# Altium schematic sheet SCH001 - 14-port switch Power & Voltage converter.SchDoc
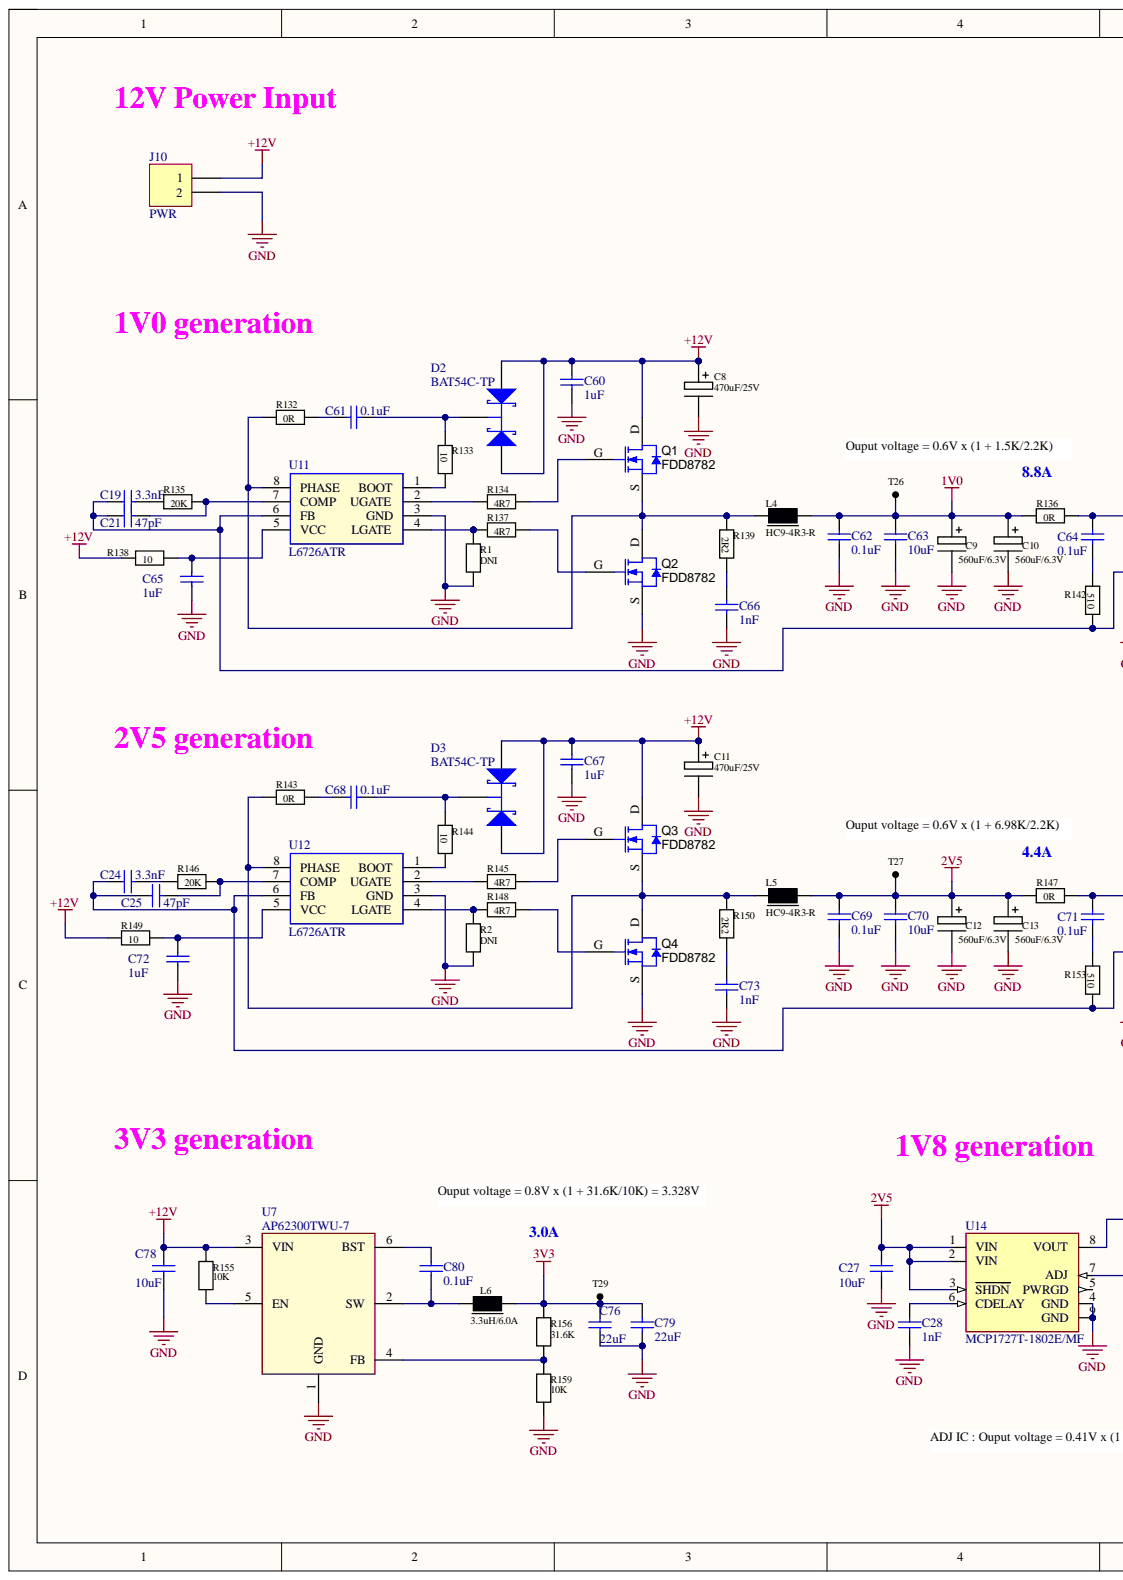
[diagram p0: SCH001 - 14-port switch Power & Voltage converter.SchDoc, left]
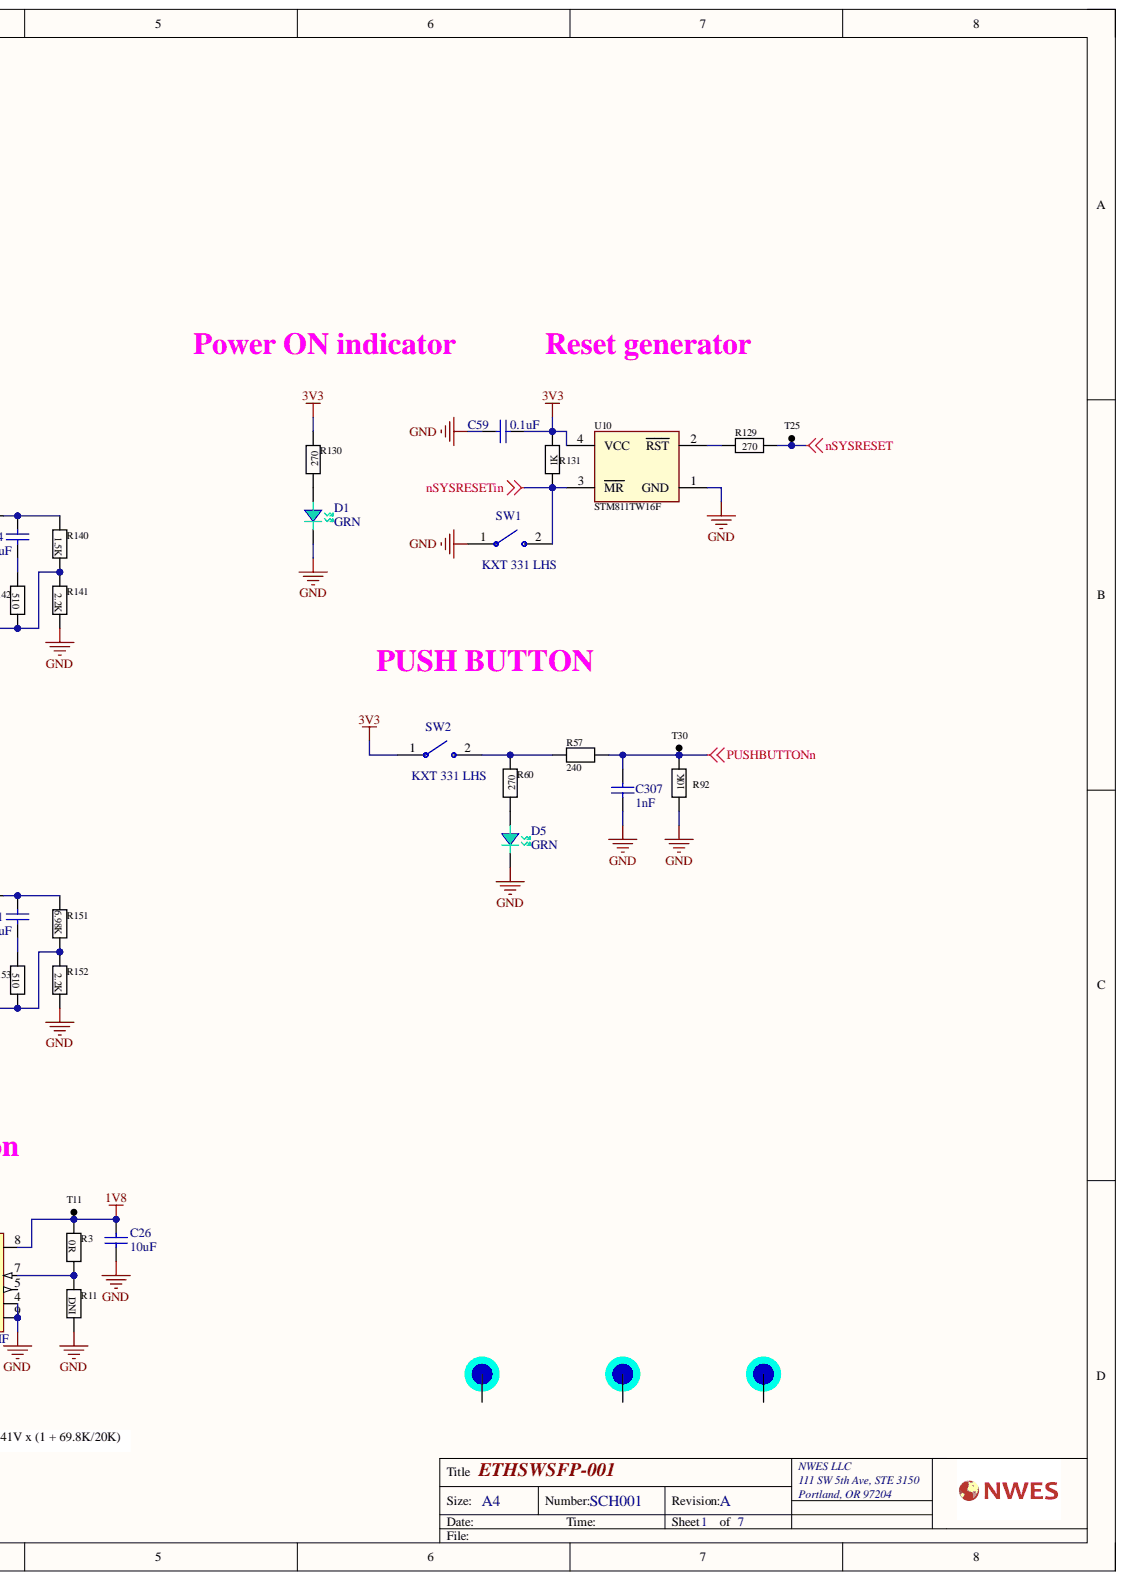
[diagram p1: SCH001 - 14-port switch Power & Voltage converter.SchDoc, right]

## Parts
C8  470uF/25V  [CAPAE1030X1680N]
C9  560uF/6.3V  [ELEC_8.3_8.3_7]
C10  560uF/6.3V  [ELEC_8.3_8.3_7]
C11  470uF/25V  [CAPAE1030X1680N]
C12  560uF/6.3V  [ELEC_8.3_8.3_7]
C13  560uF/6.3V  [ELEC_8.3_8.3_7]
C19  3.3nF  [CAPC1005X55USR]
C21  47pF  [CAPC1005X55USR]
C24  3.3nF  [CAPC1005X55USR]
C25  47pF  [CAPC1005X55USR]
C26  10uF  [CAPC1005X61USR]
C27  10uF  [CAPC1005X61USR]
C28  1nF  [CAPC1005X61USR]
C59  0.1uF  [CAPC1005X61USR]
C60  1uF  [CAPC1005X61USR]
C61  0.1uF  [CAPC1005X61USR]
C62  0.1uF  [CAPC1005X61USR]
C63  10uF  [CAPC1005X61USR]
C64  0.1uF  [CAPC1005X61USR]
C65  1uF  [CAPC1005X61USR]
C66  1nF  [CAPC1005X61USR]
C67  1uF  [CAPC1005X61USR]
C68  0.1uF  [CAPC1005X61USR]
C69  0.1uF  [CAPC1005X61USR]
C70  10uF  [CAPC1005X61USR]
C71  0.1uF  [CAPC1005X61USR]
C72  1uF  [CAPC1005X61USR]
C73  1nF  [CAPC1005X61USR]
C76  22uF  [CAP_0603]
C78  10uF  [CAPC1005X61USR]
C79  22uF  [CAP_0603]
C80  0.1uF  [CAPC1005X61USR]
C307  1nF  [CAPC1005X61USR]
D1  GRN  [LED_0603]
D2  BAT54C-TP  [SOT-23]
D3  BAT54C-TP  [SOT-23]
D5  GRN  [LED_0603]
J10  PWR  [MOLEX_282834-2]
L4  HC9-4R3-R  [HC9-4R3-R]
L5  HC9-4R3-R  [HC9-4R3-R]
L6  3.3uH/6.0A  [INDPM7366X300N]
MH1  MH_1.5mm  [MH_3mm]  (value not drawn)  (no BOM)
MH2  MH_1.5mm  [MH_3mm]  (value not drawn)  (no BOM)
MH3  MH_1.5mm  [MH_3mm]  (value not drawn)  (no BOM)
Q1  FDD8782  [TO-252]
Q2  FDD8782  [TO-252]
Q3  FDD8782  [TO-252]
Q4  FDD8782  [TO-252]
R1  DNI  [RESC1005X40USR]
R2  DNI  [RESC1005X40USR]
R3  0R  [RESC1005X40USR]
R11  DNI  [RESC1005X40USR]
R57  240  [RESC1005X40USR]
R60  270  [RESC1005X40USR]
R92  10K  [RESC1005X40USR]
R129  270  [RESC1005X40USR]
R130  270  [RESC1005X40USR]
R131  1K  [RESC1005X40USR]
R132  0R  [RESC1005X40USR]
R133  10  [RESC3116X65N]
R134  4R7  [RESC2012X65USR]
R135  20K  [RESC1005X40USR]
R136  0R  [RESC1005X40USR]
R137  4R7  [RESC2012X65USR]
R138  10  [RESC3116X65N]
R139  2R2  [RESC2012X65USR]
R140  1.5K  [RESC1005X40USR]
R141  2.2K  [RESC1005X40USR]
R142  510  [RESC1005X40USR]
R143  0R  [RESC1005X40USR]
R144  10  [RESC3116X65N]
R145  4R7  [RESC2012X65USR]
R146  20K  [RESC1005X40USR]
R147  0R  [RESC1005X40USR]
R148  4R7  [RESC2012X65USR]
R149  10  [RESC3116X65N]
R150  2R2  [RESC2012X65USR]
R151  6.98K  [RESC1005X40USR]
R152  2.2K  [RESC1005X40USR]
R153  510  [RESC1005X40USR]
R155  10K  [RESC1005X40USR]
R156  31.6K  [RESC1005X40USR]
R159  10K  [RESC1005X40USR]
SW1  KXT 331 LHS  [SW_KXT 331]
SW2  KXT 331 LHS  [SW_KXT 331]
T11  TP_S  [TP 1mm]  (value not drawn)  (no BOM)
T25  TP_S  [TP 1mm]  (value not drawn)  (no BOM)
T26  TP_S  [TP 1mm]  (value not drawn)  (no BOM)
T27  TP_S  [TP 1mm]  (value not drawn)  (no BOM)
T29  TP_S  [TP 1mm]  (value not drawn)  (no BOM)
T30  TP_S  [TP 1mm]  (value not drawn)  (no BOM)
U7  AP62300TWU-7  [TSOT23-6]
U10  STM811TW16F  [SOT23-4]
U11  L6726ATR  [SOIC127P600X175-8N]
U12  L6726ATR  [SOIC127P600X175-8N]
U14  MCP1727T-1802E/MF  [DFN300X300X100-9N]

## Nets
1V0: C9.1 C10.1 C62.1 C63.1 L4.2 R136.2 T26.1
1V8: C26.1 R3.1 T11.1 U14.8(VOUT)
2V5: C12.1 C13.1 C27.1 C69.1 C70.1 L5.2 R147.2 T27.1 U14.1(VIN) U14.2(VIN) U14.3(SHDN)
3V3: C59.1 C76.2 C79.1 L6.2 R130.1 R131.1 R156.2 SW2.1 T29.1 U10.4(VCC)
+12V: C8.1 C11.1 C60.2 C67.2 C78.1 D2.1 D2.2 D3.1 D3.2 J10.1 Q1.D Q3.D R138.2 R149.2 R155.1 U7.3(VIN)
GND: C8.2 C9.2 C10.2 C11.2 C12.2 C13.2 C26.2 C27.2 C28.1 C59.2 C60.1 C62.2 C63.2 C65.1 C66.1 C67.1 C69.2 C70.2 C72.1 C73.1 C76.1 C78.2 C79.2 C307.2 D1.2 D5.2 J10.2 Q2.S Q4.S R1.2 R2.2 R11.2 R92.2 R141.2 R152.2 R159.2 SW1.1 U7.1(GND) U10.1(GND) U11.3(GND) U12.3(GND) U14.4(GND) U14.9(GND)
nSYSRESET: R129.2 T25.1
nSYSRESETin: R131.2 SW1.2 U10.3(MR)
PUSHBUTTONn: C307.1 R57.2 R92.1 T30.1
NetC19_1: C19.1 C21.1 R140.2 R141.1 R142.2 U11.6(FB)
NetC19_2: C19.2 R135.1
NetC21_2: C21.2 R135.2 U11.7(COMP)
NetC24_1: C24.1 C25.1 R151.2 R152.1 R153.2 U12.6(FB)
NetC24_2: C24.2 R146.1
NetC25_2: C25.2 R146.2 U12.7(COMP)
NetC28_2: C28.2 U14.6(CDELAY)
NetC61_1: C61.1 D2.3 R133.1
NetC61_2: C61.2 R132.1
NetC64_1: C64.1 R136.1 R140.1
NetC64_2: C64.2 R142.1
NetC65_2: C65.2 R138.1 U11.5(VCC)
NetC66_2: C66.2 R139.2
NetC68_1: C68.1 D3.3 R144.1
NetC68_2: C68.2 R143.1
NetC71_1: C71.1 R147.1 R151.1
NetC71_2: C71.2 R153.1
NetC72_2: C72.2 R149.1 U12.5(VCC)
NetC73_2: C73.2 R150.2
NetC80_1: C80.1 U7.6(BST)
NetC80_2: C80.2 L6.1 U7.2(SW)
NetD1_1: D1.1 R130.2
NetD5_1: D5.1 R60.2
NetL4_1: L4.1 Q1.S Q2.D R132.2 R139.1 U11.8(PHASE)
NetL5_1: L5.1 Q3.S Q4.D R143.2 R150.1 U12.8(PHASE)
NetQ1_G: Q1.G R134.1
NetQ2_G: Q2.G R137.1
NetQ3_G: Q3.G R145.1
NetQ4_G: Q4.G R148.1
NetR1_1: R1.1 R137.2 U11.4(LGATE)
NetR2_1: R2.1 R148.2 U12.4(LGATE)
NetR3_2: R3.2 R11.1 U14.7(ADJ)
NetR57_1: R57.1 R60.1 SW2.2
NetR129_1: R129.1 U10.2(RST)
NetR133_2: R133.2 U11.1(BOOT)
NetR134_2: R134.2 U11.2(UGATE)
NetR144_2: R144.2 U12.1(BOOT)
NetR145_2: R145.2 U12.2(UGATE)
NetR155_2: R155.2 U7.5(EN)
NetR156_1: R156.1 R159.1 U7.4(FB)

Not connected: MH1 1; MH2 1; MH3 1; U14 5(PWRGD)

## Library data of the parts
C8 C11 = C+: CAP ALUM POLY 470UF 20% 25V SMD; Manufacturer: Nichicon; Manufacturer Part Number: PCR1E471MCL1GS; Tolerance: +/-20%; Component Kind: Standard; Supplier 1: Digi-Key; Supplier Part Number 1: 493-13756-1-ND; Value: 470uF/25V; Library Name: 15-port switch V1.SCHLIB
C9 C10 C12 C13 = C+: CAP ALUM POLY 560UF 20% 6.3V SMD; Manufacturer: Nichicon; Manufacturer Part Number: RHA0J561MCN1GS; Tolerance: +/-20%; Component Kind: Standard; Supplier 1: Digi-Key; Supplier Part Number 1: 493-3772-1-ND; Value: 560uF/6.3V; Library Name: 15-port switch V1.SCHLIB
C19 C24 = CAP CER 3300PF 50V X7R 0402: Manufacturer: Samsung Electro-Mechanics; Manufacturer Part Number: CL05B332KB5NNNC; PCB Footprint: 0402; ROOM: Supply; Component Kind: Standard; Description: CAP CER 3300PF 50V X7R 0402; Supplier 1: Digi-Key; Supplier Part Number 1: 1276-1552-1-ND; value: 3.3nF; Library Name: 15-port switch V1.SCHLIB
C21 C25 = CAP CER 47PF 50V C0G/NP0 0402: Manufacturer: Murata Electronics; Manufacturer Part Number: GRM1555C1H470GA01J; PCB Footprint: 0402; ROOM: Supply; Component Kind: Standard; Description: CAP CER 47PF 50V C0G/NP0 0402; Supplier 1: Digi-Key; Supplier Part Number 1: 490-7758-2-ND; value: 47pF; Library Name: 15-port switch V1.SCHLIB
C26 C27 C63 C70 C78 = CAP CER 10UF 10V X5R 0402: Manufacturer: Samsung Electro-Mechanics; Manufacturer Part Number: CL05A106MP8NUB8; Component Kind: Standard; Description: CAP CER 10UF 10V X5R 0402; Package: 0402; Supplier 1: Digi-Key; Supplier Part Number 1: 1276-6830-1-ND; value: 10uF; Library Name: 15-port switch V1.SCHLIB
C28 C66 C73 C307 = CAP CER 1000PF 50V X7R 0402: Manufacturer: KEMET; Manufacturer Part Number: C0402C102K5RAC7411; Component Kind: Standard; Description: CAP CER 1000PF 50V X7R 0402; Package: 0402; Supplier 1: Digi-Key; Supplier Part Number 1: 399-C0402C102K5RAC7411CT-ND; value: 1nF; Library Name: 15-port switch V1.SCHLIB
C59 C61 C62 C64 C68 C69 C71 C80 = CAP CER 0.1UF 50V X7R 0402: Manufacturer: Murata Electronics; Manufacturer Part Number: GRM155R71H104KE14D; Component Kind: Standard; Description: CAP CER 0.1UF 50V X7R 0402; Package: 0402; Supplier 1: Digi-Key; Supplier Part Number 1: 490-10700-2-ND; value: 0.1uF; Library Name: 15-port switch V1.SCHLIB
C60 C65 C67 C72 = CAP CER 1UF 25V X5R 0402: Manufacturer: Murata Electronics; Manufacturer Part Number: GRM155R61E105MA12D; Component Kind: Standard; Description: CAP CER 1UF 25V X5R 0402; Package: 0402; Supplier 1: Digi-Key; Supplier Part Number 1: 490-10018-1-ND; value: 1uF; Library Name: 15-port switch V1.SCHLIB
C76 C79 = CAP CER 22UF 6.3V X5R 0603: Manufacturer: Samsung Electro-Mechanics; Manufacturer Part Number: CL10A226MQ8NRNC; Component Kind: Standard; Description: CAP CER 22UF 6.3V X5R 0603; Package: 0603; Supplier 1: Digi-Key; Supplier Part Number 1: 1276-1193-1-ND; value: 22uF; Library Name: 15-port switch V1.SCHLIB
D1 D5 = 0603LED_GRN: LED GREEN CLEAR CHIP 0603 SMD; Manufacturer: Lite-On Inc.; Manufacturer Part Number: LTST-C191GKT; Unit Cost, Qty 1: $0.68; Unit Cost, Qty 500: $0.293; Component Kind: Standard; Supplier 1: Digi-Key; Supplier Part Number 1: 160-1443-1-ND; Value: GRN; Library Name: 15-port switch V1.SCHLIB
D2 D3 = BAT54C: DIODE ARRAY SCHOTTKY 30V SOT23-3; Manufacturer: Micro Commercial Co; Component Kind: Standard; ComponentLink1Description: Manufacturer URL; ComponentLink1URL: http://www.nxp.com/; ComponentLink2Description: Datasheet; ComponentLink2URL: http://www.nxp.com/documents/data_sheet/BAT54_SER.pdf; Manufacturer Part Number: BAT54C-TP; Supplier 1: Digi-Key; Supplier Part Number 1: BAT54CTPMSCT-ND; Library Name: 15-port switch V1.SCHLIB
J10 = Header 2: TERM BLK 2P SIDE ENT 2.54MM PCB; Manufacturer: TE Connectivity; Manufacturer Part Number: 282834-2; Component Kind: Standard; Signal Integrity: Connector; Supplier 1: Digi-Key; Supplier Part Number 1: A98333-ND; Library Name: 15-port switch V1.SCHLIB
L4 L5 = L1: FIXED IND 4.3UH 13A 5.9 MOHM SMD; Manufacturer: Eaton - Electronics Division; Value: 4.3uH/13A; Component Kind: Standard; Current Rating: 13A; Manufacturer Part Number: HC9-4R3-R; Supplier 1: DigiKey; Supplier Part Number 1: 513-1354-2-ND; Library Name: 15-port switch V1.SCHLIB
L6 = L1: FIXED IND 3.3UH 6A 30 MOHM SMD; Manufacturer: Eaton - Electronics Division; Manufacturer Part Number: HCM0703-3R3-R; Current Rating: 6.0A; Component Kind: Standard; Supplier 1: Digi-Key; Supplier Part Number 1: 513-1704-1-ND; Value: 3.3uH/6.0A; Library Name: 15-port switch V1.SCHLIB
MH1-MH3 = MH_1.5mm: Component Description: Fiducial 1mm; Library Name: 15-port switch V1.SCHLIB
Q1-Q4 = MOSFET:N: MOSFET N-CH 25V 81A DPAK; Manufacturer: Infineon Technologies; Manufacturer Part Number: IRLR8256TRPBF; ChType: N; Component Kind: Standard; ComponentLink1Description: Datasheet link; ComponentLink1URL: http://www.fairchildsemi.com/ds/BS/BSS138.pdf; CompType: MOSFET; MountType: SMT; Supplier 1: Digi-Key; Supplier Part Number 1: IRLR8256TRPBFCT-ND; VdsMax_V: 25V; Library Name: 26-port switch.SCHLIB
R1 R2 R11 = RES DNI: RES 0 OHM JUMPER 1/16W 0402; Manufacturer: Yageo; Manufacturer Part Number: RC0402FR-070RL; Value: 0R; Component Kind: Standard; Height: 0.40mm; Package: 0402; Supplier 1: Digi-Key; Supplier Part Number 1: 311-0.0LRCT-ND; Library Name: 15-port switch V1.SCHLIB
R3 R132 R136 R143 R147 = RES 0 OHM JUMPER 1/16W 0402: Manufacturer: Yageo; Manufacturer Part Number: RC0402FR-070RL; Component Kind: Standard; Description: RES 0 OHM JUMPER 1/16W 0402; Height: 0.40mm; Package: 0402; Supplier 1: Digi-Key; Supplier Part Number 1: 311-0.0LRCT-ND; Value: 0R; Library Name: 15-port switch V1.SCHLIB
R57 = RES 240 OHM 1% 1/16W 0402: Manufacturer: Yageo; Manufacturer Part Number: RC0402FR-07240RL; Component Kind: Standard; Description: RES 240 OHM 1% 1/16W 0402; Height: 0.40mm; Package: 0402; Supplier 1: Digi-Key; Supplier Part Number 1: 311-240LRCT-ND; Value: 240; Library Name: 15-port switch V1.SCHLIB
R60 R129 R130 = RES 270 OHM 1% 1/16W 0402: Manufacturer: Yageo; Manufacturer Part Number: RC0402FR-07270RL; Component Kind: Standard; Description: RES 270 OHM 1% 1/16W 0402; Height: 0.40mm; Package: 0402; Supplier 1: Digi-Key; Supplier Part Number 1: 311-270LRCT-ND; Value: 270; Library Name: 15-port switch V1.SCHLIB
R92 R155 R159 = RES SMD 10K OHM 1% 1/16W 0402: Manufacturer: Yageo; Manufacturer Part Number: AC0402FR-0710KL; Component Kind: Standard; Description: RES SMD 10K OHM 1% 1/16W 0402; Height: 0.40mm; Package: 0402; Supplier 1: Digi-Key; Supplier Part Number 1: YAG3436TR-ND; Value: 10K; Library Name: 15-port switch V1.SCHLIB
R131 = RES SMD 1K OHM 1% 1/16W 0402: Manufacturer: Yageo; Manufacturer Part Number: RC0402FR-071KP; Component Kind: Standard; Description: RES SMD 1K OHM 1% 1/16W 0402; Height: 0.40mm; Package: 0402; Supplier 1: Digi-Key; Supplier Part Number 1: YAG1254CT-ND; Value: 1K; Library Name: 15-port switch V1.SCHLIB
R133 R138 R144 R149 = RES 10 OHM 1% 1/4W 1206: Manufacturer: Yageo; Manufacturer Part Number: RMCF1206FT10R0; Component Kind: Standard; Description: RES 10 OHM 1% 1/4W 1206; Height: 0.70mm; Package: 1206; Supplier 1: Digi-Key; Supplier Part Number 1: RMCF1206FT10R0CT-ND; Value: 10; Library Name: 15-port switch V1.SCHLIB
R134 R137 R145 R148 = RES SMD 4.7 OHM 1% 0.4W 0805: Manufacturer: Rohm Semiconductor; Manufacturer Part Number: ESR10EZPF4R70; Component Kind: Standard; Description: RES SMD 4.7 OHM 1% 0.4W 0805; Height: 0.65mm; Package: 0805; Supplier 1: Digi-Key; Supplier Part Number 1: RHM4.70AECT-ND; Value: 4R7; Library Name: 15-port switch V1.SCHLIB
R135 R146 = RES 20K OHM 1% 1/16W 0402: Manufacturer: Yageo; Manufacturer Part Number: RC0402FR-0720KL; Component Kind: Standard; Description: RES 20K OHM 1% 1/16W 0402; Height: 0.40mm; Package: 0402; Supplier 1: Digi-Key; Supplier Part Number 1: 311-20.0KLRCT-ND; Value: 20K; Library Name: 15-port switch V1.SCHLIB
R139 R150 = RES 2.2 OHM 1% 1/8W 0805: Manufacturer: Stackpole Electronics Inc; Manufacturer Part Number: RMCF0805FT2R20; Component Kind: Standard; Description: RES 2.2 OHM 1% 1/8W 0805; Height: 0.65mm; Package: 0805; Supplier 1: Digi-Key; Supplier Part Number 1: RMCF0805FT2R20CT-ND; Value: 2R2; Library Name: 15-port switch V1.SCHLIB
R140 = RES 1.5K OHM 1% 1/16W 0402: Manufacturer: Yageo; Manufacturer Part Number: RC0402FR-071K5L; Component Kind: Standard; Description: RES 1.5K OHM 1% 1/16W 0402; Height: 0.40mm; Package: 0402; Supplier 1: Digi-Key; Supplier Part Number 1: 311-1.50KLRCT-ND; Value: 1.5K; Library Name: 15-port switch V1.SCHLIB
R141 R152 = RES 2.2K OHM 1% 1/16W 0402: Manufacturer: Yageo; Manufacturer Part Number: RC0402FR-072K2L; Component Kind: Standard; Description: RES 2.2K OHM 1% 1/16W 0402; Height: 0.40mm; Package: 0402; Supplier 1: Digi-Key; Supplier Part Number 1: 311-2.20KLRCT-ND; Value: 2.2K; Library Name: 15-port switch V1.SCHLIB
R142 R153 = RES SMD 510 OHM 1% 1/16W 0402: Manufacturer: Yageo; Manufacturer Part Number: RT0402FRE07510RL; Component Kind: Standard; Description: RES SMD 510 OHM 1% 1/16W 0402; Height: 0.40mm; Package: 0402; Supplier 1: Digi-Key; Supplier Part Number 1: 13-RT0402FRE07510RLCT-ND; Value: 510; Library Name: 15-port switch V1.SCHLIB
R151 = RES 6.98K OHM 1% 1/16W 0402: Manufacturer: Yageo; Manufacturer Part Number: RC0402FR-076K98L; Component Kind: Standard; Description: RES 6.98K OHM 1% 1/16W 0402; Height: 0.40mm; Package: 0402; Supplier 1: Digi-Key; Supplier Part Number 1: YAG3220CT-ND; Value: 6.98K; Library Name: 15-port switch V1.SCHLIB
R156 = RES 31.6K OHM 1% 1/16W 0402: Manufacturer: Stackpole Electronics Inc; Manufacturer Part Number: RMCF0402FT31K6; Component Kind: Standard; Description: RES 31.6K OHM 1% 1/16W 0402; Height: 0.40mm; Package: 0402; Supplier 1: Digi-Key; Supplier Part Number 1: RMCF0402FT31K6CT-ND; Value: 31.6K; Library Name: 15-port switch V1.SCHLIB
SW1 SW2 = B3U-1000P: SWITCH TACTILE SPST-NO 0.02A 15V; Manufacturer: C&K; Component Kind: Standard; Manufacturer Part Number: KXT 331 LHS; Package: None; Price: None; Supplier 1: Digi-Key; Supplier Part Number 1: CKN10779TR-ND; Library Name: 15-port switch V1.SCHLIB
T11 T25-T27 T29 T30 = TP_S: test point; Library Name: 26-port switch.SCHLIB
U7 = AP62300TWU-7: DCDC CONV HV BUCK,TSOT26,T&R,3K; Manufacturer: Diodes Incorporated; Component Kind: Standard; Manufacturer Part Number: AP62300TWU-7; Price: None; Supplier 1: Digi-Key; Supplier Part Number 1: 31-AP62300TWU-7CT-ND; Library Name: 15-port switch V1.SCHLIB
U10 = MAX811TEUS: IC SUPERVISOR 1 CHANNEL SOT23-4; Manufacturer: STMicroelectronics; Component Kind: Standard; Manufacturer Part Number: STM811TW16F; Mounting Type: Surface Mount; Pricing 1: 1=0.52, 25=0.4328 (USD); Stock 1: 189; Supplier 1: Digi-Key; Supplier Part Number 1: 497-3349-1-ND; Value: STM811TW16F; Library Name: 15-port switch V1.SCHLIB
U11 U12 = RT8105GS: IC REG CTRLR BUCK 8SOIC; Manufacturer: STMicroelectronics; Geometry.Height: 1.753mm; Component Kind: Standard; Manufacturer Part Number: L6726ATR; Supplier 1: Digi-Key; Supplier Part Number 1: 497-5906-1-ND; Library Name: 15-port switch V1.SCHLIB
U14 = MCP1727T-ADJE/MF: IC REG LINEAR 1.8V 1.5A 8DFN; Manufacturer: Microchip Technology; Manufacturer Part Number: MCP1727-1802E/MF; Component Kind: Standard; Supplier 1: Digi-Key; Supplier Part Number 1: MCP1727-1802E/MF-ND; Library Name: 16-port switch V1.SCHLIB
